# Altium schematic sheet I_PRV_UWS_B2_1_0a_MCU.SchDoc
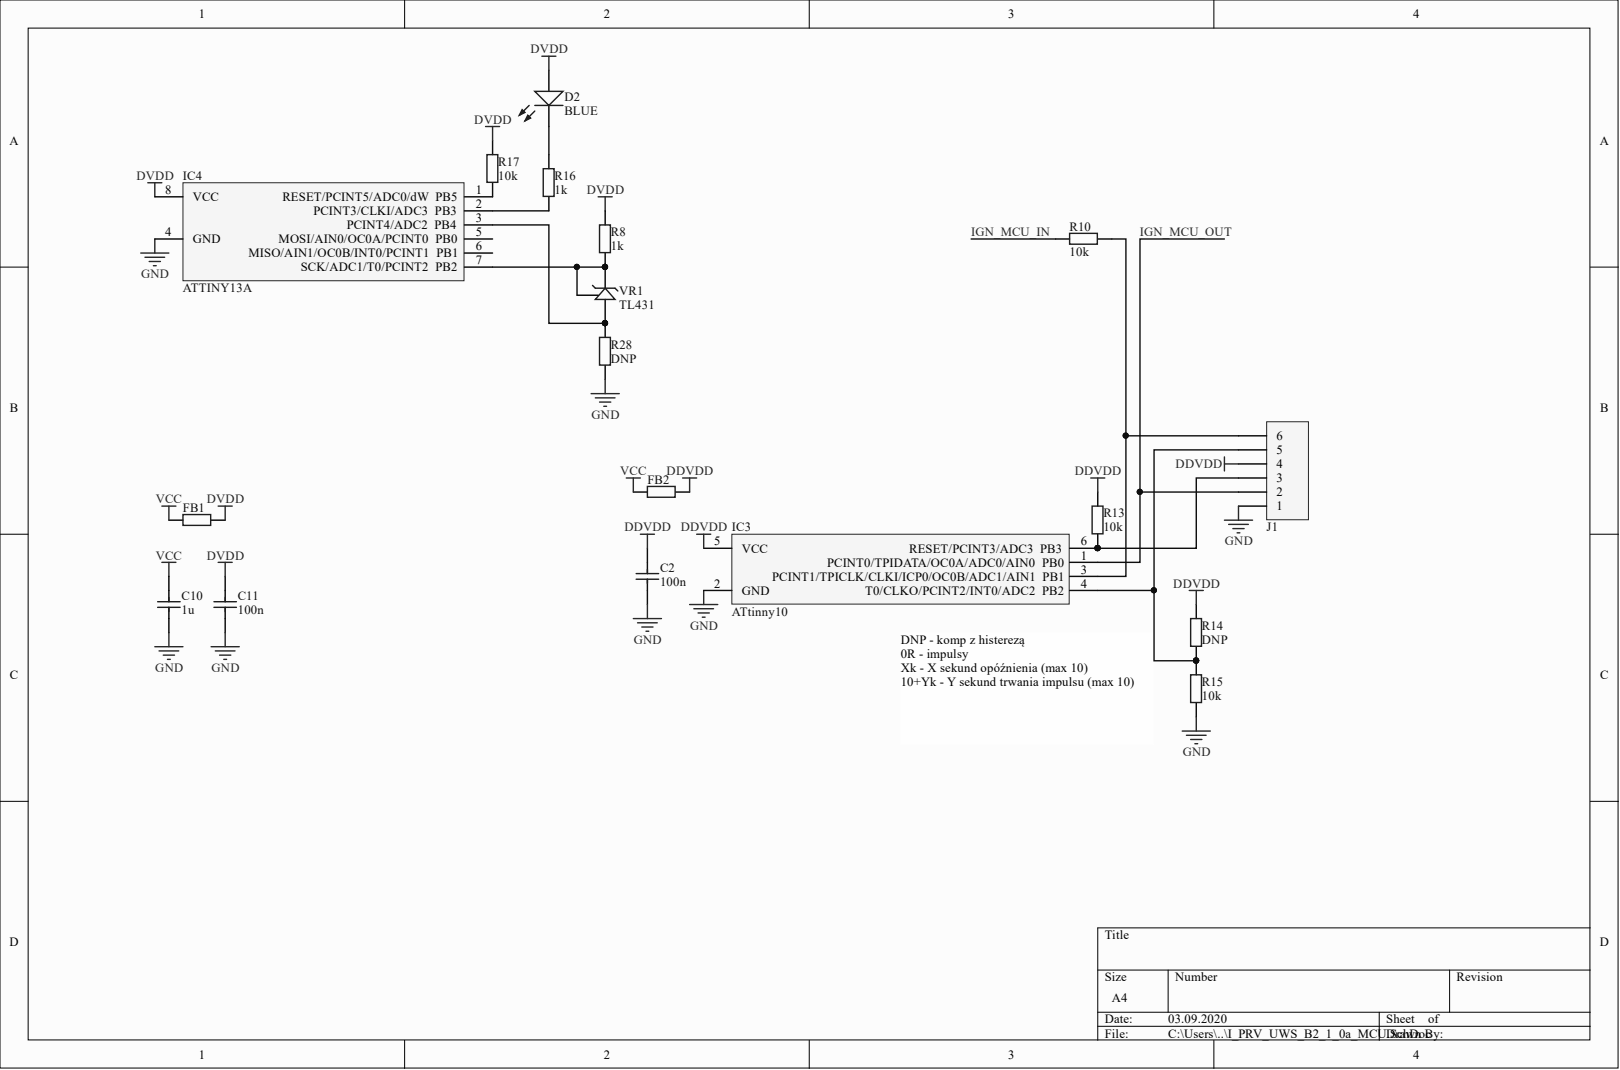
[diagram p0: I_PRV_UWS_B2_1_0a_MCU.SchDoc]

## Parts
C2  value 100n  [SMD0402_CAP]  (hidden comment: Capacitor)
C10  value 1u  [SMD0402_CAP]  (hidden comment: Capacitor)
C11  value 100n  [SMD0402_CAP]  (hidden comment: Capacitor)
D2  value BLUE  [SMD0603_LED]  (hidden comment: LED)
FB1  Resistor  [SMD0402_RES]  (value not drawn)
FB2  Resistor  [SMD0402_RES]  (value not drawn)
IC3  ATtinny10  [SOT23-6]
IC4  ATTINY13A  [SOIC_8]
J1  Connector 6 pin  [6x1 connector 1.27mm]  (value not drawn)
R8  value 1k  [SMD0402_RES]  (hidden comment: Resistor)
R10  value 10k  [SMD0402_RES]  (hidden comment: Resistor)
R13  value 10k  [SMD0402_RES]  (hidden comment: Resistor)
R14  value DNP  [SMD0402_RES]  (hidden comment: Resistor)
R15  value 10k  [SMD0402_RES]  (hidden comment: Resistor)
R16  value 1k  [SMD0402_RES]  (hidden comment: Resistor)
R17  value 10k  [SMD0402_RES]  (hidden comment: Resistor)
R28  value DNP  [SMD0402_RES]  (hidden comment: Resistor)
VR1  TL431  [SOT23]

## Nets
DDVDD: C2.2 FB2.1 IC3.5(VCC) J1.4 R13.2 R14.2
DVDD: C11.2 D2.2 FB1.1 IC4.8(VCC) R8.2 R17.2
GND: C2.1 C10.1 C11.1 IC3.2(GND) IC4.4(GND) J1.1 R15.2 R28.1
VCC: C10.2 FB1.2 FB2.2
IGN_MCU_IN: R10.1
IGN_MCU_OUT: IC3.1(PB0) J1.2
NetD2_1: D2.1 R16.1
NetIC3_3: IC3.3(PB1) J1.6 R10.2
NetIC3_4: IC3.4(PB2) J1.5 R14.1 R15.1
NetIC3_6: IC3.6(PB3) J1.3 R13.1
NetIC4_1: IC4.1(PB5) R17.1
NetIC4_2: IC4.2(PB3) R16.2
NetIC4_3: IC4.3(PB4) R28.2 VR1.3
NetIC4_7: IC4.7(PB2) R8.1 VR1.1 VR1.2

Not connected: IC4 5(PB0) 6(PB1)

## Library data of the parts
IC3 = ATtiny10: Component Kind: Standard; Library Name: BM_UWS.SchLib
VR1 = TL431: Voltage reference; Component Kind: Standard; LCSC Part #: C181103; Library Name: BM_UWS.SchLib
C2 C10 C11 = Capacitor: Component Kind: Standard; Description: Capacitor; Library Name: KD_SCH_LIB.SchLib
  C2 C11: LCSC Part #: C1525
  C10: LCSC Part #: C52923
D2 = LED: Component Kind: Standard; Description: LED; LCSC Part #: C72041; Library Name: KD_SCH_LIB.SchLib
FB1 FB2 R8 R10 R13-R17 R28 = Resistor: Component Kind: Standard; Description: Resistor; Library Name: KD_SCH_LIB.SchLib
  FB1 FB2: LCSC Part #: C85817
  R8 R16: LCSC Part #: C11702
  R10 R13 R15 R17: LCSC Part #: C25744
IC4 = ATTINY13A: Component Kind: Standard; LCSC Part #: C40382; Library Name: BM_UWS.SchLib
J1 = Connector 6 pin: Component Kind: Standard; Library Name: BM_SCH_LIB.SchLib
